# Altium schematic sheet 04_LVDC_Driver.SchDoc
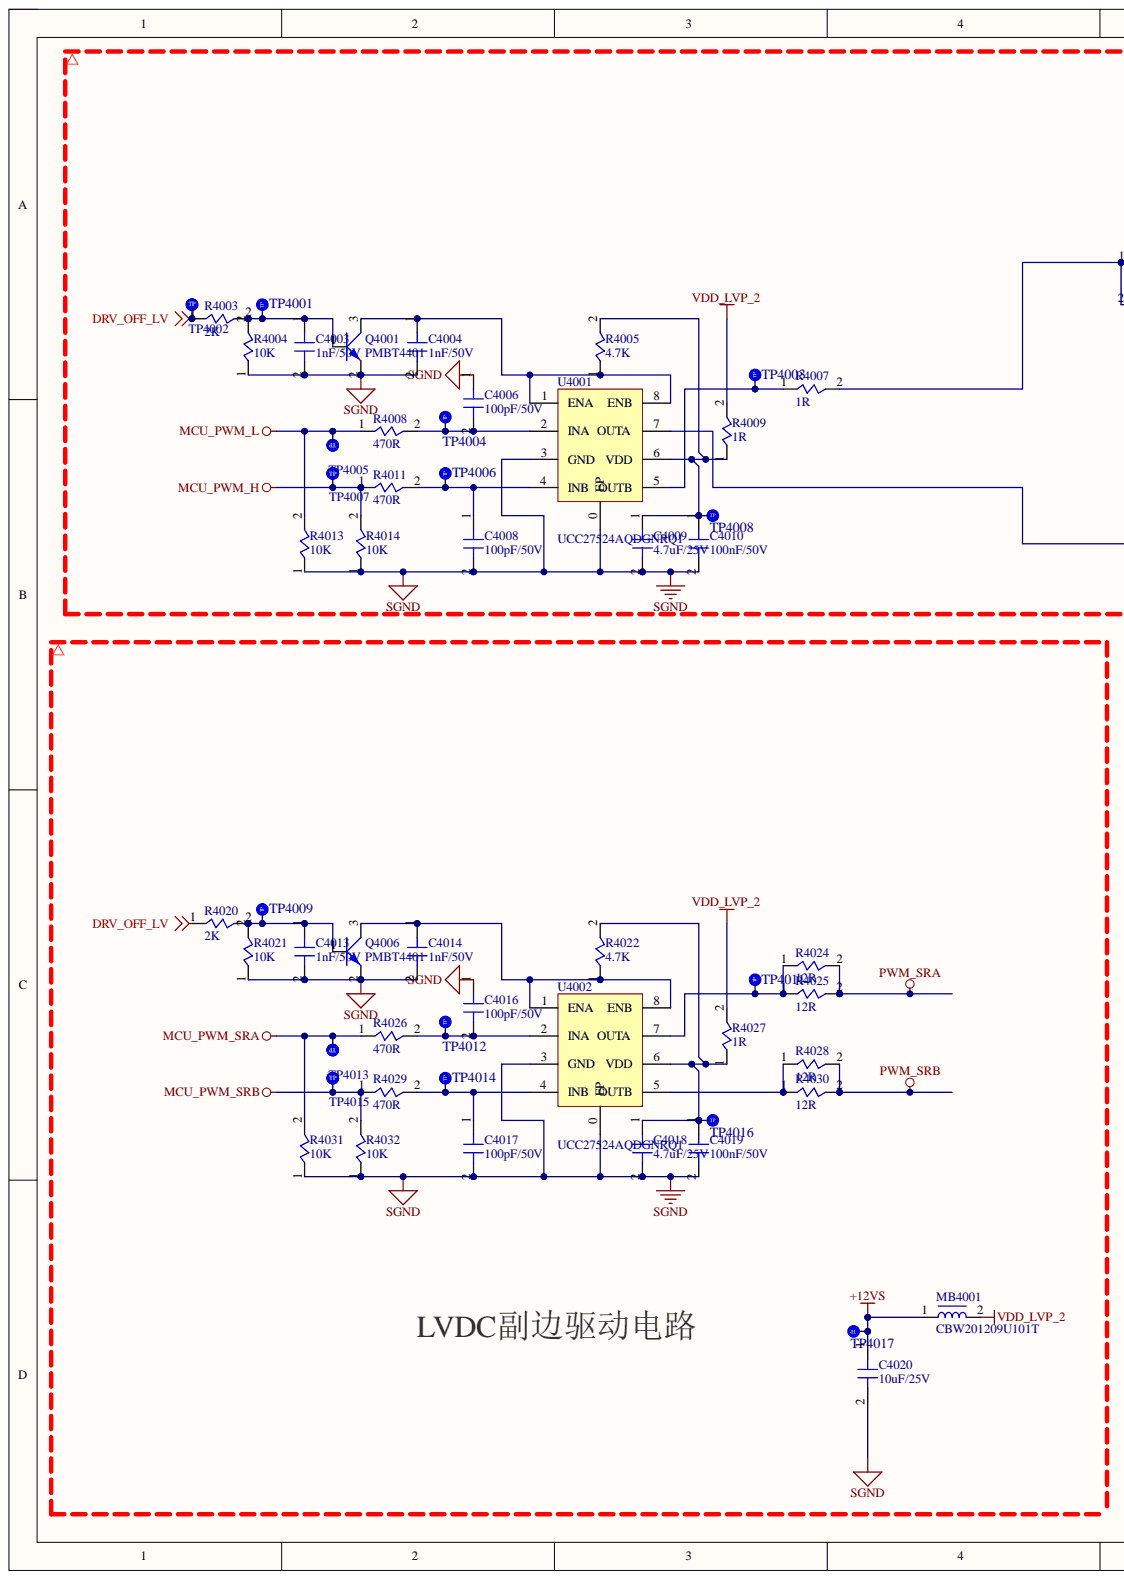
[diagram p0: 04_LVDC_Driver.SchDoc, left]
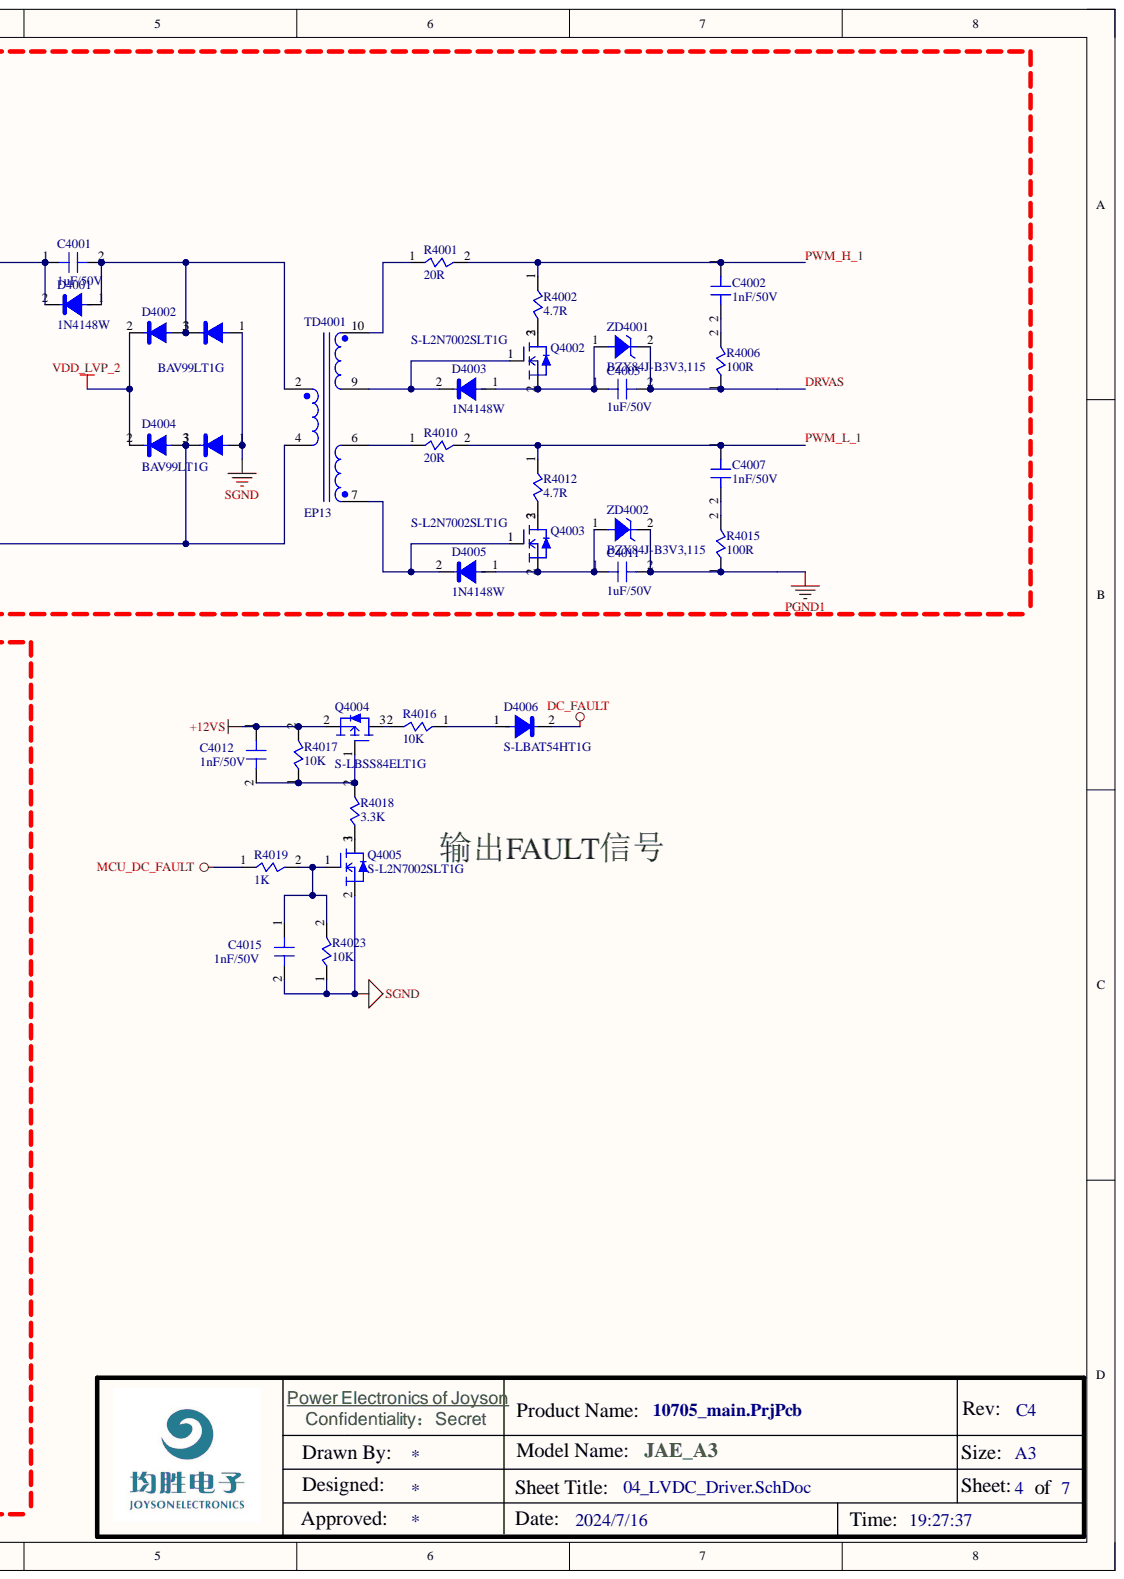
[diagram p1: 04_LVDC_Driver.SchDoc, right]

## Parts
C4001  1uF  value 1uF/50V  [C-0805]
C4002  1nF  value 1nF/50V  [C-0603]
C4003  1nF  value 1nF/50V  [C-0402]
C4004  1nF  value 1nF/50V  [C-0402]
C4005  1uF  value 1uF/50V  [C-0805]
C4006  100pF  value 100pF/50V  [C-0402]
C4007  1nF  value 1nF/50V  [C-0603]
C4008  100pF  value 100pF/50V  [C-0402]
C4009  4.7uF  value 4.7uF/25V  [C-0805]
C4010  100nF  value 100nF/50V  [C-0603]
C4011  1uF  value 1uF/50V  [C-0805]
C4012  1nF  value 1nF/50V  [C-0402]
C4013  1nF  value 1nF/50V  [C-0402]
C4014  1nF  value 1nF/50V  [C-0402]
C4015  1nF  value 1nF/50V  [C-0402]
C4016  100pF  value 100pF/50V  [C-0402]
C4017  100pF  value 100pF/50V  [C-0402]
C4018  4.7uF  value 4.7uF/25V  [C-0805]
C4019  100nF  value 100nF/50V  [C-0603]
C4020  10uF  value 10uF/25V  [C-1206]
D4001  1N4148W  [SOD-123]
D4002  BAV99LT1G  [SOT-23]
D4003  1N4148W  [SOD-123]
D4004  BAV99LT1G  [SOT-23]
D4005  1N4148W  [SOD-123]
D4006  S-LBAT54HT1G  [SOD-323]
MB4001  CBW201209U101T  [L-0603]
Q4001  PMBT4401  [SOT-23]
Q4002  S-L2N7002SLT1G  [SOT-23]
Q4003  S-L2N7002SLT1G  [SOT-23]
Q4004  S-LBSS84ELT1G  [SOT-23]
Q4005  S-L2N7002SLT1G  [SOT-23]
Q4006  PMBT4401  [SOT-23]
R4001  20R  [R-1206]
R4002  4.7R  [R-1206]
R4003  2K  [R-0402]
R4004  10K  [R-0402]
R4005  4.7K  [R-0603]
R4006  100R  [R-0603]
R4007  1R  [R-0805]
R4008  470R  [R-0603]
R4009  1R  [R-0805]
R4010  20R  [R-1206]
R4011  470R  [R-0603]
R4012  4.7R  [R-1206]
R4013  10K  [R-0402]
R4014  10K  [R-0402]
R4015  100R  [R-0603]
R4016  10K  [R-0402]
R4017  10K  [R-0402]
R4018  3.3K  [R-0402]
R4019  1K  [R-0402]
R4020  2K  [R-0402]
R4021  10K  [R-0402]
R4022  4.7K  [R-0603]
R4023  10K  [R-0402]
R4024  12R  [R-1206]
R4025  12R  [R-1206]
R4026  470R  [R-0603]
R4027  1R  [R-0805]
R4028  12R  [R-1206]
R4029  470R  [R-0603]
R4030  12R  [R-1206]
R4031  10K  [R-0402]
R4032  10K  [R-0402]
TD4001  EP13  [EP13H5+5]
TP4001  TEST PAD-0.7  [STP-0.7M]  (value not drawn)  (no BOM)
TP4002  TP1.0  [TP1.0]  (value not drawn)  (no BOM)
TP4003  TEST PAD-0.7  [STP-0.7M]  (value not drawn)  (no BOM)
TP4004  TEST PAD-0.7  [STP-0.7M]  (value not drawn)  (no BOM)
TP4005  TP1.0  [TP1.0]  (value not drawn)  (no BOM)
TP4006  TEST PAD-0.7  [STP-0.7M]  (value not drawn)  (no BOM)
TP4007  TP1.0  [TP1.0]  (value not drawn)  (no BOM)
TP4008  TEST PAD-0.7  [STP-0.7M]  (value not drawn)  (no BOM)
TP4009  TEST PAD-0.7  [STP-0.7M]  (value not drawn)  (no BOM)
TP4011  TEST PAD-0.7  [STP-0.7M]  (value not drawn)  (no BOM)
TP4012  TEST PAD-0.7  [STP-0.7M]  (value not drawn)  (no BOM)
TP4013  TP1.0  [TP1.0]  (value not drawn)  (no BOM)
TP4014  TEST PAD-0.7  [STP-0.7M]  (value not drawn)  (no BOM)
TP4015  TP1.0  [TP1.0]  (value not drawn)  (no BOM)
TP4016  TEST PAD-0.7  [STP-0.7M]  (value not drawn)  (no BOM)
TP4017  TEST PAD-0.7  [STP-0.7M]  (value not drawn)  (no BOM)
U4001  UCC27524AQDGNRQ1  [VSSOP-8-EP]
U4002  UCC27524AQDGNRQ1  [VSSOP-8-EP]
ZD4001  BZX84J-B3V3,115  [SOD-323]
ZD4002  BZX84J-B3V3,115  [SOD-323]

## Nets
+12VS: C4012.1 C4020.1 MB4001.1 Q4004.2 R4017.2 TP4017.1
DC_FAULT: D4006.2
DRV_OFF_LV: R4003.1 R4020.1 TP4002.1
MCU_DC_FAULT: R4019.1
MCU_PWM_H: R4011.1 R4014.2 TP4007.1
MCU_PWM_L: R4008.1 R4013.2 TP4005.1
MCU_PWM_SRA: R4026.1 R4031.2 TP4013.1
MCU_PWM_SRB: R4029.1 R4032.2 TP4015.1
PGND1: C4011.2 R4015.1 ZD4002.2
PWM_SRA: R4024.2 R4025.2
PWM_SRB: R4028.2 R4030.2
SGND: C4003.2 C4004.2 C4006.1 C4008.2 C4009.2 C4010.2 C4013.2 C4014.2 C4015.2 C4016.1 C4017.2 C4018.2 C4019.2 C4020.2 D4002.1 D4004.1 Q4001.2 Q4005.2 Q4006.2 R4004.1 R4013.1 R4014.1 R4021.1 R4023.1 R4031.1 R4032.1 U4001.0(EP) U4001.3(GND) U4002.0(EP) U4002.3(GND)
VDD_LVP_2: D4002.2 D4004.2 MB4001.2 R4009.2 R4027.2
DRVAS: C4005.2 R4006.1 ZD4001.2
PWM_H_1: C4002.1 R4001.2 R4002.1
PWM_L_1: C4007.1 R4010.2 R4012.1
NetC4001_1: C4001.1 D4001.2 R4007.2
NetC4001_2: C4001.2 D4001.1 D4002.3 TD4001.2
NetC4002_2: C4002.2 R4006.2
NetC4003_1: C4003.1 Q4001.1 R4003.2 R4004.2 TP4001.1
NetC4004_1: C4004.1 Q4001.3 R4005.1 U4001.1(ENA) U4001.8(ENB)
NetC4005_1: C4005.1 D4003.1 Q4002.2 ZD4001.1
NetC4006_2: C4006.2 R4008.2 TP4004.1 U4001.2(INA)
NetC4007_2: C4007.2 R4015.2
NetC4008_1: C4008.1 R4011.2 TP4006.1 U4001.4(INB)
NetC4009_1: C4009.1 C4010.1 R4005.2 R4009.1 TP4008.1 U4001.6(VDD)
NetC4011_1: C4011.1 D4005.1 Q4003.2 ZD4002.1
NetC4012_2: C4012.2 Q4004.1 R4017.1 R4018.2
NetC4013_1: C4013.1 Q4006.1 R4020.2 R4021.2 TP4009.1
NetC4014_1: C4014.1 Q4006.3 R4022.1 U4002.1(ENA) U4002.8(ENB)
NetC4015_1: C4015.1 Q4005.1 R4019.2 R4023.2
NetC4016_2: C4016.2 R4026.2 TP4012.1 U4002.2(INA)
NetC4017_1: C4017.1 R4029.2 TP4014.1 U4002.4(INB)
NetC4018_1: C4018.1 C4019.1 R4022.2 R4027.1 TP4016.1 U4002.6(VDD)
NetD4003_2: D4003.2 Q4002.1 TD4001.9
NetD4004_3: D4004.3 TD4001.4 U4001.7(OUTA)
NetD4005_2: D4005.2 Q4003.1 TD4001.7
NetD4006_1: D4006.1 R4016.1
NetQ4002_3: Q4002.3 R4002.2
NetQ4003_3: Q4003.3 R4012.2
NetQ4004_3: Q4004.3 R4016.2
NetQ4005_3: Q4005.3 R4018.1
NetR4001_1: R4001.1 TD4001.10
NetR4007_1: R4007.1 TP4003.1 U4001.5(OUTB)
NetR4010_1: R4010.1 TD4001.6
NetR4024_1: R4024.1 R4025.1 TP4011.1 U4002.7(OUTA)
NetR4028_1: R4028.1 R4030.1 U4002.5(OUTB)

## Library data of the parts
C4001 C4005 C4011 = 1101032036-00: 贴片电容封装; Brand: YAGEO; Database Table Name: Capacitor-SMD; Grade: 汽车级; Material Name: Capacitor; SAP Number: 09701-716/0000; Spec/Modle: Capacitor-MLCC-0805-X7R-±10%-50V-1uF; Temprature: -55℃ to +125℃; Type: 贴片; Vendor No: AC0805KKX7R9BB105; Component Kind: Standard; Library Name: Database_Libs1.DbLib; Material No: 1101032036-00; Value2: 1uF
C4002 C4007 = 1101022010-00: 贴片电容封装; Brand: YAGEO; Database Table Name: Capacitor-SMD; Grade: 汽车级; Material Name: Capacitor; SAP Number: 05521-212/0000; Spec/Modle: Capacitor-MLCC-0603-X7R-±10%-50V-1nF; Temprature: -55℃ to +125℃; Type: 贴片; Vendor No: AC0603KRX7R9BB102; Component Kind: Standard; Library Name: Database_Libs1.DbLib; Material No: 1101022010-00; Value2: 1nF
C4003 C4004 C4012-C4015 = 1101012010-00: 贴片电容封装; Brand: YAGEO; Database Table Name: Capacitor-SMD; Grade: 汽车级; Material Name: Capacitor; SAP Number: 09801-025/0000; Spec/Modle: Capacitor-MLCC-0402-X7R-±10%-50V-1nF; Temprature: -55℃ to +125℃; Type: 贴片; Vendor No: AC0402KRX7R9BB102; Component Kind: Standard; Library Name: Database_Libs1.DbLib; Material No: 1101012010-00; Value2: 1nF
C4006 C4008 C4016 C4017 = 1101012001-00: 贴片电容封装; Brand: YAGEO; Database Table Name: Capacitor-SMD; Grade: 汽车级; Material Name: Capacitor; Spec/Modle: Capacitor-MLCC-0402-X7R-±10%-50V-100pF; Temprature: -55℃ to +125℃; Type: 贴片; Vendor No: AC0402KRX7R9BB101; Component Kind: Standard; Library Name: Database_Libs1.DbLib; Material No: 1101012001-00; Value2: 100pF
C4009 C4018 = 1101032039-00: 贴片电容封装; Brand: YAGEO; Database Table Name: Capacitor-SMD; Grade: 汽车级; Material Name: Capacitor; Spec/Modle: Capacitor-MLCC-0805-X7R-±10%-25V-4.7uF; Temprature: -55℃ to +125℃; Type: 贴片; Vendor No: AC0805KKX7R8BB475; Component Kind: Standard; Library Name: Database_Libs1.DbLib; Material No: 1101032039-00; Value2: 4.7uF
C4010 C4019 = 1101022028-00: 贴片电容封装; Brand: YAGEO; Database Table Name: Capacitor-SMD; Grade: 汽车级; Material Name: Capacitor; SAP Number: 05521-133/0000; Spec/Modle: Capacitor-MLCC-0603-X7R-±10%-50V-100nF; Temprature: -55℃ to +125℃; Type: 贴片; Vendor No: AC0603KRX7R9BB104; Component Kind: Standard; Library Name: Database_Libs1.DbLib; Material No: 1101022028-00; Value2: 100nF
C4020 = 1101042015-00: 贴片电容封装; Brand: YAGEO; Database Table Name: Capacitor-SMD; Grade: 汽车级; Material Name: Capacitor; Spec/Modle: Capacitor-MLCC-1206-X7R-±10%-25V-10uF; Temprature: -55℃ to +125℃; Type: 贴片; Vendor No: AC1206KKX7R8BB106; Component Kind: Standard; Library Name: Database_Libs1.DbLib; Material No: 1101042015-00; Value2: 10uF
D4001 D4003 D4005 = 1205030001-00: 二极管SMD-2P封装; Brand: 宏迦橙; Database Table Name: Diode; Grade: 工业级; Spec/Modle: Diode-SFR-SOD123-100V-150mA; Temprature: -55℃ to +150℃; Type: 贴片; Component Kind: Standard; Library Name: Database_Libs1.DbLib; Material Name: Diode; Material No: 1205030001-00; Value: 1N4148W; Vendor No: 1N4148W
D4002 D4004 = 1203060001-00: 二极管SOT23串联封装; Brand: onsemi; Database Table Name: Diode; Grade: 工业级; SAP Number: 06005-404/0000; Spec/Modle: Diode-Schottky-SOT23-100V-0.7A-mark(A7M); Temprature: -65℃ to +150℃; Type: 贴片; Component Kind: Standard; Library Name: Database_Libs1.DbLib; Material Name: Diode; Material No: 1203060001-00; Value: BAV99LT1G; Vendor No: BAV99LT1G
D4006 = 1203020002-00: 二极管SMD-2P封装; Brand: LRC; Database Table Name: Diode; Grade: 工业级; SAP Number: 06005-408/0000; Spec/Modle: Diode-Schottky-SMD-SOD323-30V-200mA-mark(JV); Temprature: -55℃ to +125℃; Type: 贴片; Component Kind: Standard; Library Name: Database_Libs1.DbLib; Material Name: Diode; Material No: 1203020002-00; Value: S-LBAT54HT1G; Vendor No: S-LBAT54HT1G
MB4001 = 1503010002-00: 磁珠封装; Brand: 风华; Database Table Name: Magnetic; Grade: 工业级; Material Name: Inductor; Spec/Modle: Inductor-Magnetic bead-0603-±25%-100Ω; Temprature: -40℃ to +85℃; Component Kind: Standard; Library Name: Database_Libs1.DbLib; Material No: 1503010002-00; Type: 贴片; Value: CBW201209U101T; Vendor No: CBW201209U101T
Q4001 Q4006 = 1301030001-00: NPN三极管SOT23封装; Brand: nexperia; Database Table Name: Transistor; Grade: 工业级; SAP Number: 06005-200/0000; Spec/Modle: Transistor-NPN-SOT23-40V-600mA-hfe(100->300)-mark(2X); Temprature: -65℃ to +150℃; Type: 贴片; Component Kind: Standard; Library Name: Database_Libs1.DbLib; Material Name: Transistor; Material No: 1301030001-00; Value: PMBT4401; Vendor No: PMBT4401
Q4002 Q4003 Q4005 = 1304030001-00: NMOS管SOT23封装; Brand: LRC; Database Table Name: Transistor; Grade: 汽车级; Material Name: Mosfet; SAP Number: 06005-431/0000; Spec/Modle: Mosfet-NMOS-SOT23-60V-380mA-3.2Ω-mark(701); Temprature: -55℃ to +150℃; Type: 贴片; Component Kind: Standard; Library Name: Database_Libs1.DbLib; Material No: 1304030001-00; Value: S-L2N7002SLT1G; Vendor No: S-L2N7002SLT1G
Q4004 = 1305030001-00: PMOS管SOT23封装; Brand: LRC; Database Table Name: Transistor; Grade: 汽车级; Material Name: Mosfet; SAP Number: 06005-433/0000; Spec/Modle: Mosfet-PMOS-SOT23-(-60V)-(-0.13A)-5Ω-mark(PE); Temprature: -55℃ to +150℃; Type: 贴片; Component Kind: Standard; Library Name: Database_Libs1.DbLib; Material No: 1305030001-00; Value: S-LBSS84ELT1G; Vendor No: S-LBSS84ELT1G
R4001 R4010 = 1001040147-00: 贴片电阻封装; Brand: YAGEO; Database Table Name: Resistor-SMD; Grade: 汽车级; Material Name: Resistor; SAP Number: 05541-167/0000; Spec/Modle: Resistor-SMD-1206-1/4W-±1%-±100ppm/℃-20Ω; Temprature: -55℃ to +155℃; Vendor No: AC1206FR-0720RL; Component Kind: Standard; Library Name: Database_Libs1.DbLib; Material No: 1001040147-00; Type: 贴片; Value: 20R
R4002 R4012 = 1001040077-00: 贴片电阻封装; Brand: YAGEO; Database Table Name: Resistor-SMD; Grade: 汽车级; Material Name: Resistor; SAP Number: 05541-097/0000; Spec/Modle: Resistor-SMD-1206-1/4W-±1%-±200ppm/℃-4.7Ω; Temprature: -55℃ to +155℃; Vendor No: AC1206FR-074R7L; Component Kind: Standard; Library Name: Database_Libs1.DbLib; Material No: 1001040077-00; Type: 贴片; Value: 4.7R
R4003 R4020 = 1001010375-00: 贴片电阻封装; Brand: YAGEO; Database Table Name: Resistor-SMD; Grade: 汽车级; Material Name: Resistor; SAP Number: 05544-395/0000; Spec/Modle: Resistor-SMD-0402-1/16W-±1%-±100ppm/℃-2KΩ; Temprature: -55℃ to +155℃; Vendor No: AC0402FR-072KL; Component Kind: Standard; Library Name: Database_Libs1.DbLib; Material No: 1001010375-00; Type: 贴片; Value: 2K
R4004 R4013 R4014 R4016 R4017 R4021 R4023 R4031 R4032 = 1001010457-00: 贴片电阻封装; Brand: YAGEO; Database Table Name: Resistor-SMD; Grade: 汽车级; Material Name: Resistor; SAP Number: 05544-477/0000; Spec/Modle: Resistor-SMD-0402-1/16W-±1%-±100ppm/℃-10KΩ; Temprature: -55℃ to +155℃; Vendor No: AC0402FR-0710KL; Component Kind: Standard; Library Name: Database_Libs1.DbLib; Material No: 1001010457-00; Type: 贴片; Value: 10K
R4005 R4022 = 1001020419-00: 贴片电阻封装; Brand: YAGEO; Database Table Name: Resistor-SMD; Grade: 汽车级; Material Name: Resistor; SAP Number: 05543-439/0000; Spec/Modle: Resistor-SMD-0603-1/10W-±1%-±100ppm/℃-4.7KΩ; Temprature: -55℃ to +155℃; Vendor No: AC0603FR-074K7L; Component Kind: Standard; Library Name: Database_Libs1.DbLib; Material No: 1001020419-00; Type: 贴片; Value: 4.7K
R4006 R4015 = 1001020229-00: 贴片电阻封装; Brand: YAGEO; Database Table Name: Resistor-SMD; Grade: 汽车级; Material Name: Resistor; SAP Number: 05543-249/0000; Spec/Modle: Resistor-SMD-0603-1/10W-±1%-±100ppm/℃-100Ω; Temprature: -55℃ to +155℃; Vendor No: AC0603FR-07100RL; Component Kind: Standard; Library Name: Database_Libs1.DbLib; Material No: 1001020229-00; Type: 贴片; Value: 100R
R4007 R4009 R4027 = 1001030001-00: 贴片电阻封装; Brand: YAGEO; Database Table Name: Resistor-SMD; Grade: 汽车级; Material Name: Resistor; SAP Number: 05542-021/0000; Spec/Modle: Resistor-SMD-0805-1/8W-±1%-±200ppm/℃-1Ω; Temprature: -55℃ to +155℃; Vendor No: AC0805FR-071RL; Component Kind: Standard; Library Name: Database_Libs1.DbLib; Material No: 1001030001-00; Type: 贴片; Value: 1R
R4008 R4011 R4026 R4029 = 1001020305-00: 贴片电阻封装; Brand: YAGEO; Database Table Name: Resistor-SMD; Grade: 汽车级; Material Name: Resistor; SAP Number: 05543-325/0000; Spec/Modle: Resistor-SMD-0603-1/10W-±1%-±100ppm/℃-470Ω; Temprature: -55℃ to +155℃; Vendor No: AC0603FR-07470RL; Component Kind: Standard; Library Name: Database_Libs1.DbLib; Material No: 1001020305-00; Type: 贴片; Value: 470R
R4018 = 1001010400-00: 贴片电阻封装; Brand: YAGEO; Database Table Name: Resistor-SMD; Grade: 汽车级; Material Name: Resistor; SAP Number: 05544-420/0000; Spec/Modle: Resistor-SMD-0402-1/16W-±1%-±100ppm/℃-3.3KΩ; Temprature: -55℃ to +155℃; Vendor No: AC0402FR-073K3L; Component Kind: Standard; Library Name: Database_Libs1.DbLib; Material No: 1001010400-00; Type: 贴片; Value: 3.3K
R4019 = 1001010343-00: 贴片电阻封装; Brand: YAGEO; Database Table Name: Resistor-SMD; Grade: 汽车级; Material Name: Resistor; SAP Number: 05544-363/0000; Spec/Modle: Resistor-SMD-0402-1/16W-±1%-±100ppm/℃-1KΩ; Temprature: -55℃ to +155℃; Vendor No: AC0402FR-071KL; Component Kind: Standard; Library Name: Database_Libs1.DbLib; Material No: 1001010343-00; Type: 贴片; Value: 1K
R4024 R4025 R4028 R4030 = 1001040123-00: 贴片电阻封装; Brand: YAGEO; Database Table Name: Resistor-SMD; Grade: 汽车级; Material Name: Resistor; SAP Number: 05541-143/0000; Spec/Modle: Resistor-SMD-1206-1/4W-±1%-±100ppm/℃-12Ω; Temprature: -55℃ to +155℃; Vendor No: AC1206FR-0712RL; Component Kind: Standard; Library Name: Database_Libs1.DbLib; Material No: 1001040123-00; Type: 贴片; Value: 12R
TD4001 = 1505020004-00: 驱动变压器; Database Table Name: Magnetic; Grade: 工业级; Material Name: Inductor; Spec/Modle: Transformer-Driver; Component Kind: Standard; Library Name: Database_Libs1.DbLib; Material No: 1505020004-00; Type: 插件; Value: EP13
TP4002 TP4005 TP4007 TP4013 TP4015 = TP: Library Name: my lib.schlib
U4001 U4002 = 1405010001-00: 芯片，双路驱动芯片; Brand: TI; Database Table Name: IC; Grade: 汽车级; Spec/Modle: IC-Driver-HVSSOP8-5A-VCC（4.5V→18V)-mark（7524Q）; Temprature: -40℃ to +140℃; Component Kind: Standard; Library Name: Database_Libs1.DbLib; Material Name: IC; Material No: 1405010001-00; Type: 贴片; Value: UCC27524AQDGNRQ1; Vendor No: UCC27524AQDGNRQ1
ZD4001 ZD4002 = 1207021001-00: 稳压管2pin封装; Brand: nexperia; Database Table Name: Diode; Grade: 工业级; Spec/Modle: Diode-Zener-SOD323F-550mW-3.3V(3.23V→3.37V)-mark(SU); Temprature: -55℃ to +150℃; Component Kind: Standard; Library Name: Database_Libs1.DbLib; Material Name: Diode; Material No: 1207021001-00; Type: 贴片; Value: BZX84J-B3V3,115; Vendor No: BZX84J-B3V3,115
TP4001 TP4003 TP4004 TP4006 TP4008 TP4009 TP4011 TP4012 TP4014 TP4016 TP4017 = TEST PAD-0.7: Library Name: Test Pad.SchLib
